# Altium schematic sheet 6_DIG_VGA.SchDoc
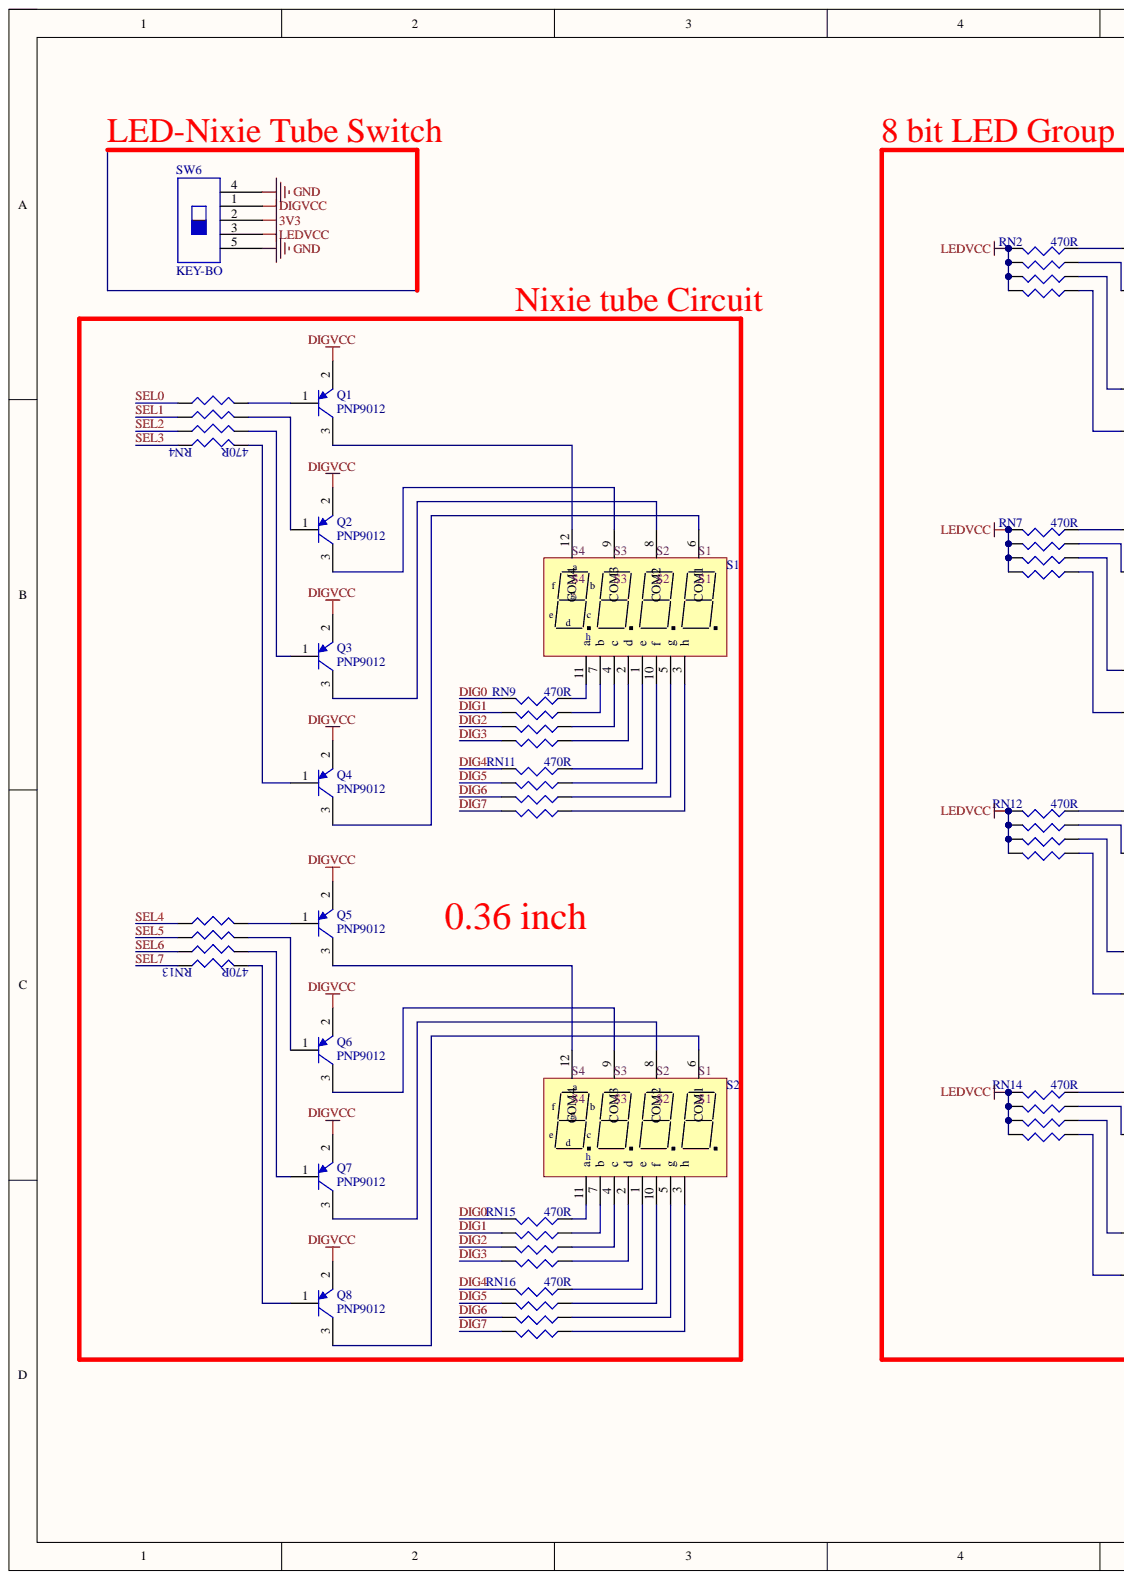
[diagram p0: 6_DIG_VGA.SchDoc, left]
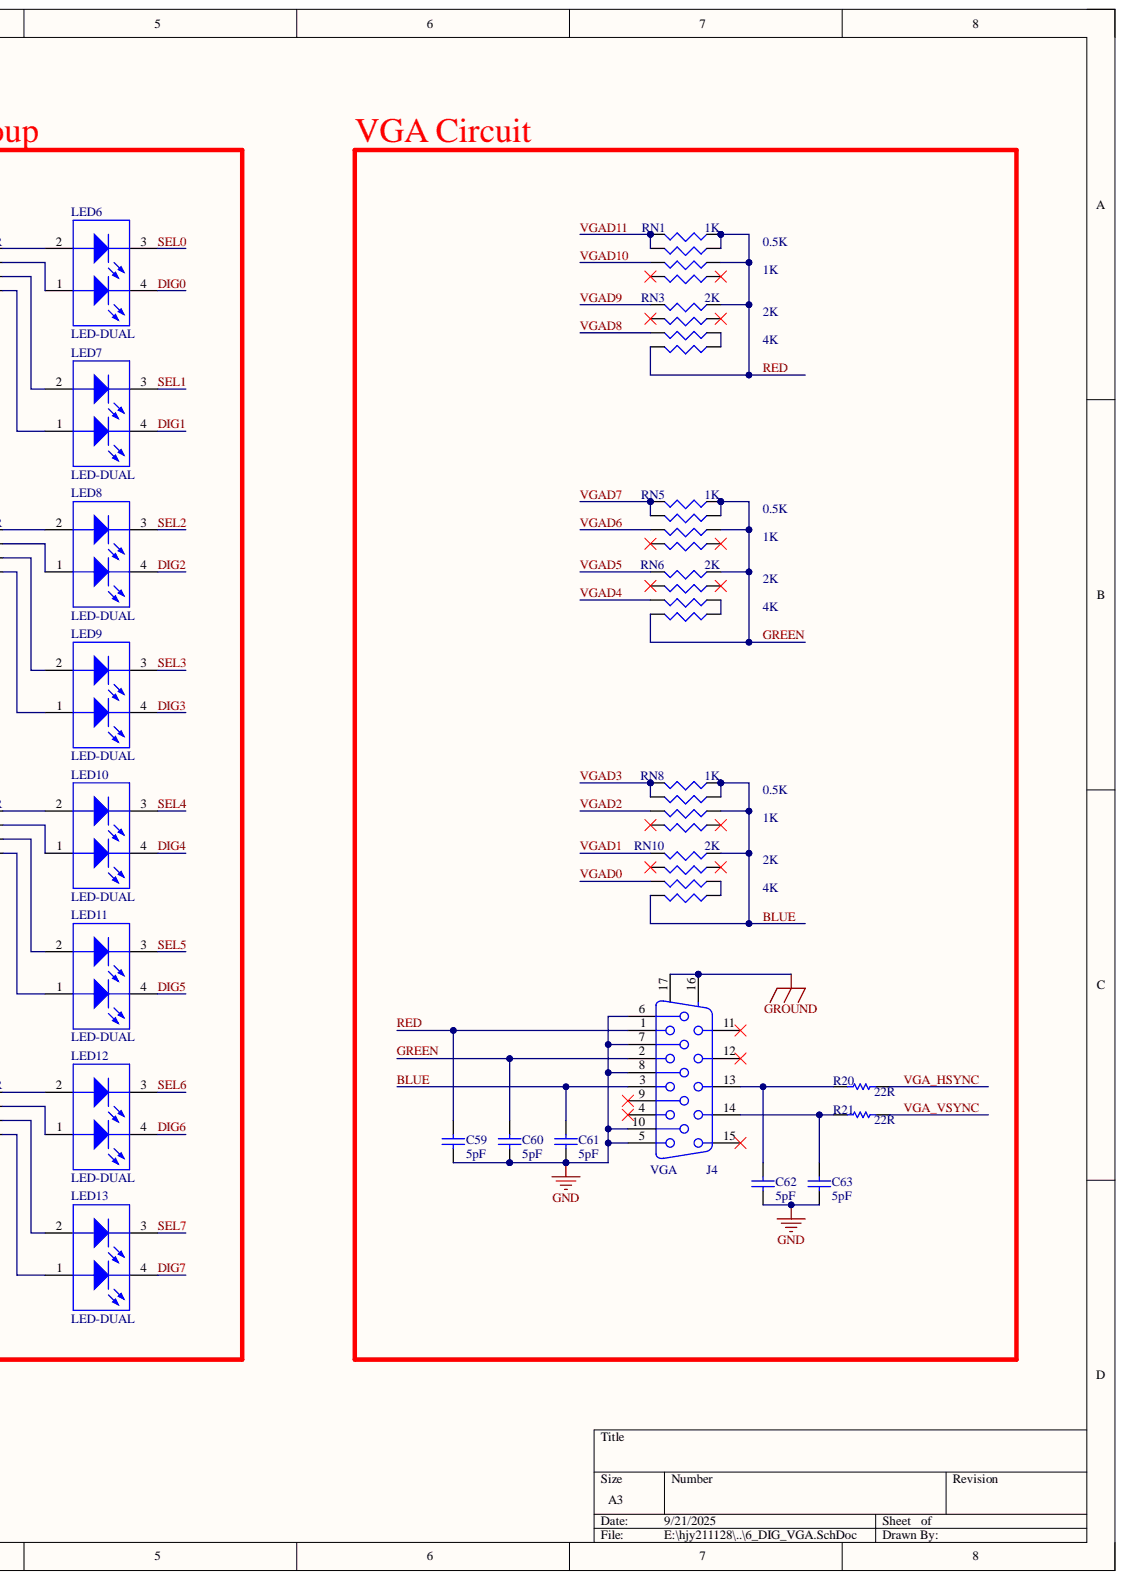
[diagram p1: 6_DIG_VGA.SchDoc, right]

## Parts
C59  5pF  [0603]
C60  5pF  [0603]
C61  5pF  [0603]
C62  5pF  [0603]
C63  5pF  [0603]
J4  VGA  [VGA15]
LED6  LED-DUAL  [LED0805X2]
LED7  LED-DUAL  [LED0805X2]
LED8  LED-DUAL  [LED0805X2]
LED9  LED-DUAL  [LED0805X2]
LED10  LED-DUAL  [LED0805X2]
LED11  LED-DUAL  [LED0805X2]
LED12  LED-DUAL  [LED0805X2]
LED13  LED-DUAL  [LED0805X2]
Q1  PNP9012  [SOT-23-3]
Q2  PNP9012  [SOT-23-3]
Q3  PNP9012  [SOT-23-3]
Q4  PNP9012  [SOT-23-3]
Q5  PNP9012  [SOT-23-3]
Q6  PNP9012  [SOT-23-3]
Q7  PNP9012  [SOT-23-3]
Q8  PNP9012  [SOT-23-3]
R20  22R  [0603]
R21  22R  [0603]
RN1  1K  [RN-8P]
RN2  470R  [RN-8P]
RN3  2K  [RN-8P]
RN4  470R  [RN-8P]
RN5  1K  [RN-8P]
RN6  2K  [RN-8P]
RN7  470R  [RN-8P]
RN8  1K  [RN-8P]
RN9  470R  [RN-8P]
RN10  2K  [RN-8P]
RN11  470R  [RN-8P]
RN12  470R  [RN-8P]
RN13  470R  [RN-8P]
RN14  470R  [RN-8P]
RN15  470R  [RN-8P]
RN16  470R  [RN-8P]
S1  SEG7_4  [SIG]  (value not drawn)
S2  SEG7_4  [SIG]  (value not drawn)
SW6  KEY-BO  [KEY_BODONG]

## Nets
3V3: SW6.2
DIGVCC: Q1.2 Q2.2 Q3.2 Q4.2 Q5.2 Q6.2 Q7.2 Q8.2 SW6.1
GND: C59.1 C60.1 C61.1 C62.1 C63.1 J4.5 J4.6 J4.7 J4.8 J4.10 SW6.4 SW6.5
GROUND: J4.16 J4.17
LEDVCC: RN2.5 RN2.6 RN2.7 RN2.8 RN7.5 RN7.6 RN7.7 RN7.8 RN12.5 RN12.6 RN12.7 RN12.8 RN14.5 RN14.6 RN14.7 RN14.8 SW6.3
BLUE: C61.2 J4.3 RN8.2 RN8.3 RN8.4 RN10.4 RN10.8
DIG0: LED6.4 RN9.5 RN15.5
DIG1: LED7.4 RN9.6 RN15.6
DIG2: LED8.4 RN9.7 RN15.7
DIG3: LED9.4 RN9.8 RN15.8
DIG4: LED10.4 RN11.5 RN16.5
DIG5: LED11.4 RN11.6 RN16.6
DIG6: LED12.4 RN11.7 RN16.7
DIG7: LED13.4 RN11.8 RN16.8
GREEN: C60.2 J4.2 RN5.2 RN5.3 RN5.4 RN6.4 RN6.8
RED: C59.2 J4.1 RN1.2 RN1.3 RN1.4 RN3.4 RN3.8
SEL0: LED6.3 RN4.8
SEL1: LED7.3 RN4.7
SEL2: LED8.3 RN4.6
SEL3: LED9.3 RN4.5
SEL4: LED10.3 RN13.8
SEL5: LED11.3 RN13.7
SEL6: LED12.3 RN13.6
SEL7: LED13.3 RN13.5
VGA_HSYNC: R20.2
VGA_VSYNC: R21.2
VGAD0: RN10.7
VGAD1: RN10.5
VGAD2: RN8.7
VGAD3: RN8.5 RN8.6
VGAD4: RN6.7
VGAD5: RN6.5
VGAD6: RN5.7
VGAD7: RN5.5 RN5.6
VGAD8: RN3.7
VGAD9: RN3.5
VGAD10: RN1.7
VGAD11: RN1.5 RN1.6
NetC62_2: C62.2 J4.13 R20.1
NetC63_2: C63.2 J4.14 R21.1
NetLED6_1: LED6.1 RN2.3
NetLED6_2: LED6.2 RN2.4
NetLED7_1: LED7.1 RN2.1
NetLED7_2: LED7.2 RN2.2
NetLED8_1: LED8.1 RN7.3
NetLED8_2: LED8.2 RN7.4
NetLED9_1: LED9.1 RN7.1
NetLED9_2: LED9.2 RN7.2
NetLED10_1: LED10.1 RN12.3
NetLED10_2: LED10.2 RN12.4
NetLED11_1: LED11.1 RN12.1
NetLED11_2: LED11.2 RN12.2
NetLED12_1: LED12.1 RN14.3
NetLED12_2: LED12.2 RN14.4
NetLED13_1: LED13.1 RN14.1
NetLED13_2: LED13.2 RN14.2
NetQ1_1: Q1.1 RN4.1
NetQ1_3: Q1.3 S1.12(COM4)
NetQ2_1: Q2.1 RN4.2
NetQ2_3: Q2.3 S1.9(COM3)
NetQ3_1: Q3.1 RN4.3
NetQ3_3: Q3.3 S1.8(COM2)
NetQ4_1: Q4.1 RN4.4
NetQ4_3: Q4.3 S1.6(COM1)
NetQ5_1: Q5.1 RN13.1
NetQ5_3: Q5.3 S2.12(COM4)
NetQ6_1: Q6.1 RN13.2
NetQ6_3: Q6.3 S2.9(COM3)
NetQ7_1: Q7.1 RN13.3
NetQ7_3: Q7.3 S2.8(COM2)
NetQ8_1: Q8.1 RN13.4
NetQ8_3: Q8.3 S2.6(COM1)
NetRN3_1: RN3.1 RN3.2
NetRN6_1: RN6.1 RN6.2
NetRN9_1: RN9.1 S1.2(d)
NetRN9_2: RN9.2 S1.4(c)
NetRN9_3: RN9.3 S1.7(b)
NetRN9_4: RN9.4 S1.11(a)
NetRN10_1: RN10.1 RN10.2
NetRN11_1: RN11.1 S1.3(h)
NetRN11_2: RN11.2 S1.5(g)
NetRN11_3: RN11.3 S1.10(f)
NetRN11_4: RN11.4 S1.1(e)
NetRN15_1: RN15.1 S2.2(d)
NetRN15_2: RN15.2 S2.4(c)
NetRN15_3: RN15.3 S2.7(b)
NetRN15_4: RN15.4 S2.11(a)
NetRN16_1: RN16.1 S2.3(h)
NetRN16_2: RN16.2 S2.5(g)
NetRN16_3: RN16.3 S2.10(f)
NetRN16_4: RN16.4 S2.1(e)

Not connected: J4 4 9 11 12 15; RN1 1 8; RN3 3 6; RN5 1 8; RN6 3 6; RN8 1 8; RN10 3 6

## Library data of the parts
C59-C63 = CAP: Capacitor; Value: 104; Component Kind: Standard; LatestRevisionDate: 17-Jul-2002; LatestRevisionNote: Re-released for DXP Platform.; PackageReference: RAD-0.3; Published: 8-Jun-2000; Library Name: cong.IntLib; Publisher: Altium Limited
J4 = Connector 15: Receptacle Assembly, 15-Pin, Sim Line Connector; Gender: Receptacle Header; PackageDocument: B; Positions: 15; Component Kind: Standard; DatasheetDocument: 24-Nov-1999; LatestRevisionDate: 17-Jul-2002; LatestRevisionNote: Re-released for DXP Platform.; Library Name: Miscellaneous Connectors.IntLib; Orientation: Right Angle; PackageReference: 788574; Published: 15-Jun-2001; Publisher: Altium Limited
Q1-Q8 = P: 贴片; Code_JEDEC: SOT-23; Component Kind: Standard; LatestRevisionDate: 17-Jul-2002; LatestRevisionNote: Re-released for DXP Platform.; PackageReference: SOT-23B; Published: 8-Jun-2000; Library Name: cong.IntLib; Publisher: Altium Limited
R20 R21 = RES: Resistor; Value: 1K; Component Kind: Standard; Library Name: My Footprint.SchLib; Published: 8-Jun-2000; Revision: July-2002: Re-released for DXP Platform.; Publisher: Altium Limited
RN1-RN16 = RN_Dup1: Component Kind: Standard
S1 S2 = S: 4位共阴数码管; Component Kind: Standard; Library Name: cong.IntLib
LED6-LED13 = LED-DUAL: Component Kind: Standard; Library Name: JJHLIB.IntLib
SW6 = KEY-BO: Component Kind: Standard; Library Name: Schlib.SchLib
